# Altium schematic sheet Esquematico_V1.SchDoc(Esquematico_V1)
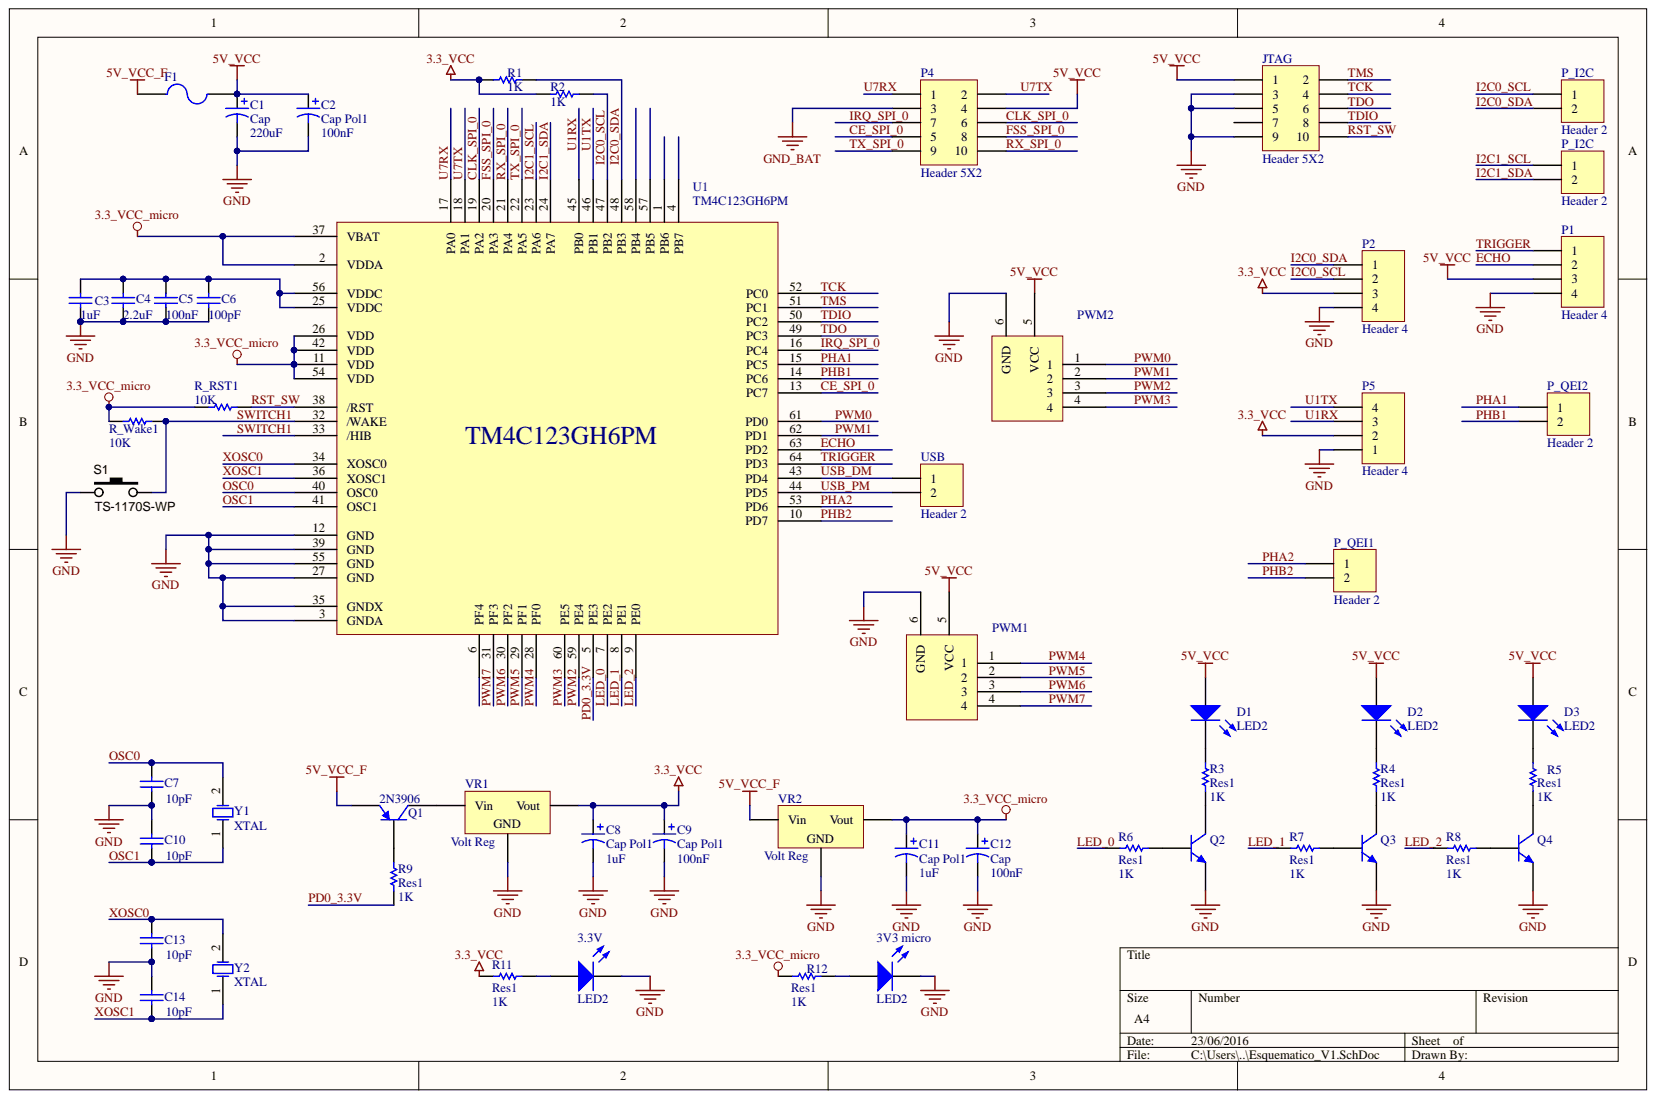
[diagram p0: Esquematico_V1.SchDoc]

## Parts
3.3V  LED2  [6-0805_L]
3V3_micro  LED2  [6-0805_M]
C1  Cap  value 220uF  [C0805]
C2  Cap Pol1  value 100nF  [6-0805_L]
C3  value 1uF  [6-0805_L]  (hidden comment: Cap)
C4  value 2.2uF  [6-0805_L]  (hidden comment: Cap)
C5  value 100nF  [6-0805_L]  (hidden comment: Cap)
C6  value 100pF  [6-0805_L]  (hidden comment: Cap)
C7  value 10pF  [C0805]  (hidden comment: Cap)
C8  Cap Pol1  value 1uF  [C0805]
C9  Cap Pol1  value 100nF  [C0805]
C10  value 10pF  [C0805]  (hidden comment: Cap)
C11  Cap Pol1  value 1uF  [C0805]
C12  Cap  value 100nF  [6-0805_L]
C13  value 10pF  [C0805]  (hidden comment: Cap)
C14  value 10pF  [C0805]  (hidden comment: Cap)
D1  LED2  [6-0805_N]
D2  LED2  [6-0805_N]
D3  LED2  [6-0805_N]
F1  Fuse 2  [6-0805_N]  (value not drawn)
JTAG  Header 5X2  [2x5x2.54 Pin Header TH V]
P1  Header 4  [HDR1X4]
P2  Header 4  [HDR1X4]
P4  Header 5X2  [SMD_HEADER]
P5  Header 4  [HDR1X4]
P_I2C  Header 2  [HDR1X2]
P_I2C  Header 2  [HDR1X2]
P_QEI1  Header 2  [HDR1X2]
P_QEI2  Header 2  [HDR1X2]
PWM1  Header 4 x 3  [Header_4x3]  (value not drawn)
PWM2  Header 4 x 3  [Header_4x3_mirror]  (value not drawn)
Q1  2N3906  [SOT-23_N]
Q2  2N3904  [SOT-23_N]  (value not drawn)
Q3  2N3904  [SOT-23_N]  (value not drawn)
Q4  2N3904  [SOT-23_N]  (value not drawn)
R1  value 1K  [C0805]  (hidden comment: Res1)
R2  value 1K  [6-0805_L]  (hidden comment: Res1)
R3  Res1  value 1K  [C0805]
R4  Res1  value 1K  [C0805]
R5  Res1  value 1K  [C0805]
R6  Res1  value 1K  [C0805]
R7  Res1  value 1K  [C0805]
R8  Res1  value 1K  [C0805]
R9  Res1  value 1K  [C0805]
R11  Res1  value 1K  [C0805]
R12  Res1  value 1K  [C0805]
R_RST1  value 10K  [C0805]  (hidden comment: Res1)
R_Wake1  value 10K  [C0805]  (hidden comment: Res1)
S1  TS-1170S-WP  [TS-1170S]
U1  TM4C123GH6PM  [SQFP50P1200X1200-64L]
USB  Header 2  [HDR1X2]
VR1  Volt Reg  [SOT223-4M]
VR2  Volt Reg  [SOT223-4M]
Y1  XTAL  [CFPX-5]
Y2  XTAL  [BCY-W2/D2.1]

## Nets
3.3_VCC: C8.1 C9.1 P2.3 P5.2 R1.1 R2.1 R11.2 VR1.3
3.3_VCC_MICRO: C11.1 C12.1 R12.1 R_RST1.1 R_Wake1.1 U1.2(VDDA) U1.11(VDD) U1.26(VDD) U1.37(VBAT) U1.42(VDD) U1.54(VDD) VR2.3
5V_VCC: C1.1 C2.1 D1.1 D2.1 D3.1 F1.2 JTAG.1 P1.3 P4.4 PWM1.5(VCC) PWM2.5(VCC)
5V_VCC_F: F1.1 Q1.1 VR2.1
GND: 3.3V.2 3V3_micro.2 C1.2 C2.2 C3.1 C4.1 C5.1 C6.1 C7.1 C8.2 C9.2 C10.2 C11.2 C12.2 C13.1 C14.2 JTAG.3 JTAG.5 JTAG.9 P1.4 P2.4 P5.1 PWM1.6(GND) PWM2.6(GND) Q2.1 Q3.1 Q4.1 S1.1 U1.3(GNDA) U1.12(GND) U1.27(GND) U1.35(GNDX) U1.39(GND) U1.55(GND) VR1.2 VR2.2
GND_BAT: P4.3
CE_SPI_0: P4.5 U1.13(PC7)
CLK_SPI_0: P4.6 U1.19(PA2)
ECHO: P1.2 U1.63(PD2)
FSS_SPI_0: P4.8 U1.20(PA3)
I2C0_SCL: P2.2 P_I2C.1 R2.2 U1.47(PB2)
I2C0_SDA: P2.1 P_I2C.2 R1.2 U1.48(PB3)
I2C1_SCL: P_I2C.1 U1.23(PA6)
I2C1_SDA: P_I2C.2 U1.24(PA7)
IRQ_SPI_0: P4.7 U1.16(PC4)
LED_0: R6.2 U1.7(PE2)
LED_1: R7.2 U1.8(PE1)
LED_2: R8.2 U1.9(PE0)
OSC0: C7.2 U1.40(OSC0) Y1.2
OSC1: C10.1 U1.41(OSC1) Y1.1
PD0_3.3V: R9.1 U1.5(PE3)
PHA1: P_QEI2.1 U1.15(PC5)
PHA2: P_QEI1.1 U1.53(PD6)
PHB1: P_QEI2.2 U1.14(PC6)
PHB2: P_QEI1.2 U1.10(PD7)
PWM0: PWM2.1 U1.61(PD0)
PWM1: PWM2.2 U1.62(PD1)
PWM2: PWM2.3 U1.59(PE4)
PWM3: PWM2.4 U1.60(PE5)
PWM4: PWM1.1 U1.28(PF0)
PWM5: PWM1.2 U1.29(PF1)
PWM6: PWM1.3 U1.30(PF2)
PWM7: PWM1.4 U1.31(PF3)
RST_SW: JTAG.10 R_RST1.2 U1.38(/RST)
RX_SPI_0: P4.10 U1.21(PA4)
SWITCH1: R_Wake1.2 S1.2 U1.32(/WAKE) U1.33(/HIB)
TCK: JTAG.4 U1.52(PC0)
TDIO: JTAG.8 U1.50(PC2)
TDO: JTAG.6 U1.49(PC3)
TMS: JTAG.2 U1.51(PC1)
TRIGGER: P1.1 U1.64(PD3)
TX_SPI_0: P4.9 U1.22(PA5)
U1RX: P5.3 U1.45(PB0)
U1TX: P5.4 U1.46(PB1)
U7RX: P4.1 U1.17(PA0)
U7TX: P4.2 U1.18(PA1)
USB_DM: U1.43(PD4) USB.1
USB_PM: U1.44(PD5) USB.2
XOSC0: C13.2 U1.34(XOSC0) Y2.2
XOSC1: C14.1 U1.36(XOSC1) Y2.1
Net3.3V_1: 3.3V.1 R11.1
Net3V3 micro_1: 3V3_micro.1 R12.2
NetC3_2: C3.2 C4.2 C5.2 C6.2 U1.25(VDDC) U1.56(VDDC)
NetD1_2: D1.2 R3.1
NetD2_2: D2.2 R4.1
NetD3_2: D3.2 R5.1
NetQ1_2: Q1.2 R9.2
NetQ1_3: Q1.3 VR1.1
NetQ2_2: Q2.2 R6.1
NetQ2_3: Q2.3 R3.2
NetQ3_2: Q3.2 R7.1
NetQ3_3: Q3.3 R4.2
NetQ4_2: Q4.2 R8.1
NetQ4_3: Q4.3 R5.2

Not connected: JTAG 7; U1 1(PB6) 4(PB7) 6(PF4) 57(PB5) 58(PB4)

## Library data of the parts
3.3V 3V3_micro D1-D3 = LED2: Typical RED, GREEN, YELLOW, AMBER GaAs LED; Component Kind: Standard; LatestRevisionDate: 17-Jul-2002; LatestRevisionNote: Re-released for DXP Platform.; Library Name: Miscellaneous Devices.IntLib; PackageDescription: SM LED; 2 Flat Leads; PackageReference: 3.2X1.6X1.1; PackageVersion: Feb-2002; Published: 8-Jun-2000; Simulation: LED2; Publisher: Altium Limited
C1 C2 C8 C9 C11 C12 = Cap Pol1: Polarized Capacitor (Radial); Component Kind: Standard; LatestRevisionDate: 17-Jul-2002; LatestRevisionNote: Re-released for DXP Platform.; Library Name: Miscellaneous Devices.IntLib; PackageDescription: Polarized Capacitor (Radial); 2 Leads; PackageReference: RB7.6-15; Published: 8-Jun-2000; Signal Integrity: Cap Pol; Simulation: CAP; Publisher: Altium Limited
C3-C7 C10 C13 C14 = Cap: Capacitor; Component Kind: Standard; LatestRevisionDate: 17-Jul-2002; LatestRevisionNote: Re-released for DXP Platform.; Library Name: Miscellaneous Devices.IntLib; PackageDescription: Radial Cap, Thru-Hole; 2 Leads; 0.3 in Pin Spacing; PackageReference: RAD-0.3; Published: 8-Jun-2000; Signal Integrity: Cap; Simulation: CAP; Publisher: Altium Limited
F1 = Fuse 2: Fuse; Component Kind: Standard; LatestRevisionDate: 17-Jul-2002; LatestRevisionNote: Re-released for DXP Platform.; Library Name: Miscellaneous Devices.IntLib; PackageDescription: Thru-Hole; 2 Leads; PackageReference: PIN-W2/E2.8; Published: 8-Jun-2000; Simulation: FUSE; Publisher: Altium Limited
JTAG P4 = Header 5X2: Header, 5-Pin, Dual row; Component Kind: Standard; LatestRevisionDate: 17-Jul-2002; LatestRevisionNote: Re-released for DXP Platform.; Library Name: Miscellaneous Connectors.IntLib; Signal Integrity: Connector; Publisher: Altium Limited
P1 P2 P5 = Header 4: Header, 4-Pin; Component Kind: Standard; LatestRevisionDate: 17-Jul-2002; LatestRevisionNote: Re-released for DXP Platform.; Library Name: Miscellaneous Connectors.IntLib; Signal Integrity: Connector; Publisher: Altium Limited
P_I2C P_I2C P_QEI1 P_QEI2 USB = Header 2: Header, 2-Pin; Component Kind: Standard; LatestRevisionDate: 17-Jul-2002; LatestRevisionNote: Re-released for DXP Platform.; Library Name: Miscellaneous Connectors.IntLib; Signal Integrity: Connector; Publisher: Altium Limited
Q1 = 2N3906: PNP General Purpose Amplifier; Component Kind: Standard; ComponentLink1Description: Manufacturer Link; ComponentLink1URL: http://www.fairchildsemi.com/; ComponentLink2Description: Datasheet; ComponentLink2URL: http://www.fairchildsemi.com/ds/2N%2F2N3906.pdf; DatasheetVersion: 1997; LatestRevisionDate: 15-Jan-2003; LatestRevisionNote: PCB Footprint 'BCY-W3/D4.7' replaced; Library Name: Miscellaneous Devices.IntLib; PackageDescription: TO, Flat Index; 3 In-Line, Axial Leads; Body Dia. 4.5mm; Leads 0.51 x 0.48 mm (max); PackageReference: TO-92A; PackageVersion: Sep-1998; Published: 8-Jun-2000; Signal Integrity: 2N3906; Simulation: 2N3906; Publisher: Altium Limited
Q2-Q4 = 2N3904: NPN General Purpose Amplifier; Component Kind: Standard; ComponentLink1Description: Manufacturer Link; ComponentLink1URL: http://www.fairchildsemi.com/; ComponentLink2Description: Datasheet; ComponentLink2URL: http://www.fairchildsemi.com/ds/2N%2F2N3904.pdf; DatasheetVersion: 1997; LatestRevisionDate: 15-Jan-2003; LatestRevisionNote: PCB Footprint 'BCY-W3/D4.7' replaced; Library Name: Miscellaneous Devices.IntLib; PackageDescription: TO, Flat Index; 3 In-Line, Axial Leads; Body Dia. 4.5mm; Leads 0.51 x 0.48 mm (max); PackageReference: TO-92A; PackageVersion: Sep-1998; Published: 8-Jun-2000; Signal Integrity: 2N3904; Simulation: 2N3904; Publisher: Altium Limited
R1-R9 R11 R12 R_RST1 R_Wake1 = Res1: Resistor; Component Kind: Standard; LatestRevisionDate: 17-Jul-2002; LatestRevisionNote: Re-released for DXP Platform.; Library Name: Miscellaneous Devices.IntLib; PackageDescription: Axial Device, Thru-Hole; 2 Leads; 0.3 in Pin Spacing; PackageReference: AXIAL-0.3; Published: 8-Jun-2000; Signal Integrity: Res; Simulation: RESISTOR; Publisher: Altium Limited
S1 = SW_SMD_PB_7.0mm: Switch SMD Tact 7.0mm Stem; Manufacturer 1: Bond-Tact; Manufacturer Part Number 1: TS-1170S-WP-200; Function: On/Off; SCDType: source; Component Kind: Standard; ComponentLink1Description: http://www.bondtact.com.hk/zaspx/productd.aspx?catno=3&subcatid=3&PageNo=2&productno=40; ComponentLink1URL: http://www.bondtact.com.hk/zaspx/productd.aspx?catno=3&subcatid=3&PageNo=2&productno=40; Library Name: PBL - Switches.SCHLIB; Package / Case: 6.5x6.5mm; 7.0mm stem
VR1 VR2 = Volt Reg: Voltage Regulator; Component Kind: Standard; LatestRevisionDate: 29-May-2009; LatestRevisionNote: IPC-7351 Footprint Added.; Library Name: Miscellaneous Devices.IntLib; PackageDescription: 3-Pin Transistor Outline Package 2.54 mm Pitch, 15.1 mm Lead Span; PackageReference: D2PAK; Published: 24-Mar-1999; Publisher: Altium Limited
Y1 Y2 = XTAL: Crystal Oscillator; Component Kind: Standard; LatestRevisionDate: 17-Jul-2002; LatestRevisionNote: Re-released for DXP Platform.; Library Name: Miscellaneous Devices.IntLib; PackageDescription: Crystal, Thru-Hole; 2 Leads; Body 3.1 x 8.2 mm (Dia.xH); PackageReference: R38; Published: 8-Jun-2000; Simulation: XTAL; Publisher: Altium Limited
PWM1 PWM2 = Header 4 x 3: Component Kind: Standard; Description: Header 4 x 3; Library Name: Conector.SchLib
U1 = TM4C123GH6PM: Component Kind: Standard; Library Name: arm.SchLib
